# Altium schematic sheet GAP_Periph1.SchDoc
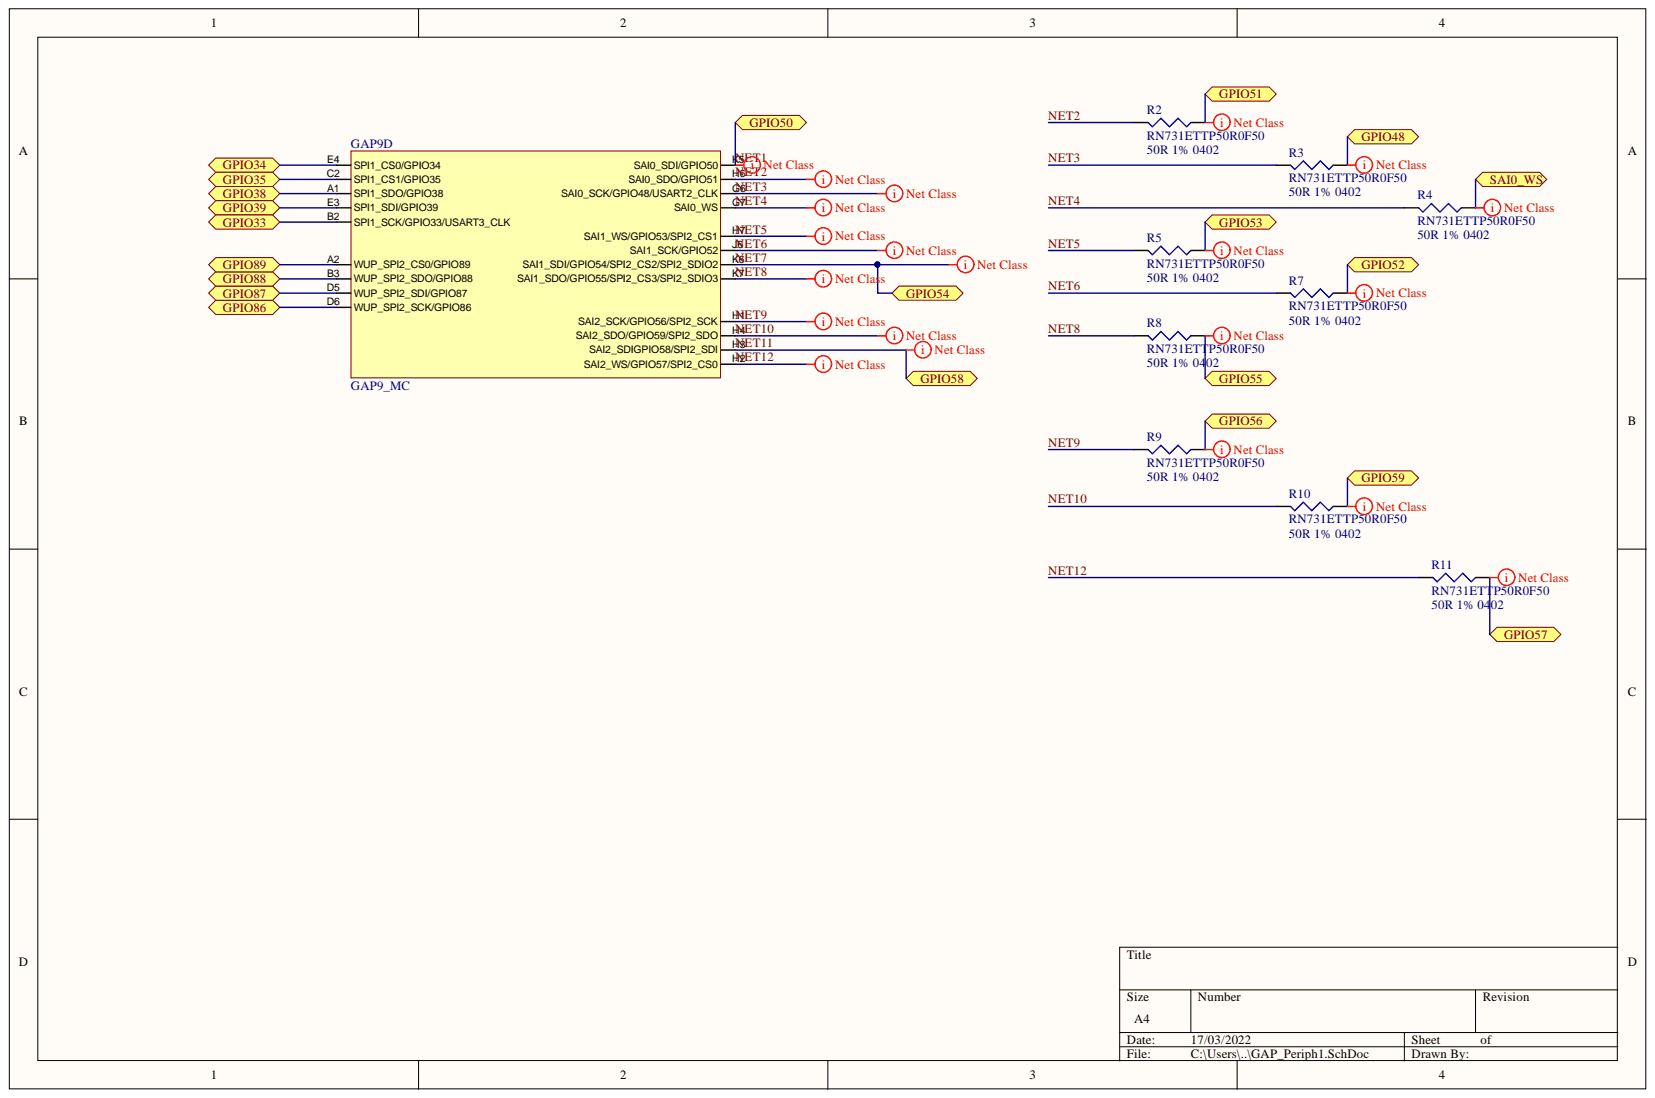
[diagram p0: GAP_Periph1.SchDoc]

## Parts
GAP9  GAP9_MC  [GAP9]  (part D)
R2  RN731ETTP50R0F50  value 50R 1% 0402  [0402]
R3  RN731ETTP50R0F50  value 50R 1% 0402  [0402]
R4  RN731ETTP50R0F50  value 50R 1% 0402  [0402]
R5  RN731ETTP50R0F50  value 50R 1% 0402  [0402]
R7  RN731ETTP50R0F50  value 50R 1% 0402  [0402]
R8  RN731ETTP50R0F50  value 50R 1% 0402  [0402]
R9  RN731ETTP50R0F50  value 50R 1% 0402  [0402]
R10  RN731ETTP50R0F50  value 50R 1% 0402  [0402]
R11  RN731ETTP50R0F50  value 50R 1% 0402  [0402]

## Ports
GPIO33, GPIO34, GPIO35, GPIO38, GPIO39, GPIO48, GPIO50, GPIO51, GPIO52, GPIO53, GPIO54, GPIO55, GPIO56, GPIO57, GPIO58, GPIO59, GPIO86, GPIO87, GPIO88, GPIO89, SAI0_WS

## Nets
GPIO33: GAP9.B2(SPI1_SCK/GPIO33/USART3_CLK) ; port GPIO33
GPIO34: GAP9.E4(SPI1_CS0/GPIO34) ; port GPIO34
GPIO35: GAP9.C2(SPI1_CS1/GPIO35) ; port GPIO35
GPIO38: GAP9.A1(SPI1_SDO/GPIO38) ; port GPIO38
GPIO39: GAP9.E3(SPI1_SDI/GPIO39) ; port GPIO39
GPIO48: R3.1 ; port GPIO48
GPIO51: R2.1 ; port GPIO51
GPIO52: R7.1 ; port GPIO52
GPIO53: R5.1 ; port GPIO53
GPIO55: R8.1 ; port GPIO55
GPIO56: R9.1 ; port GPIO56
GPIO57: R11.1 ; port GPIO57
GPIO59: R10.1 ; port GPIO59
GPIO86: GAP9.D6(WUP_SPI2_SCK/GPIO86) ; port GPIO86
GPIO87: GAP9.D5(WUP_SPI2_SDI/GPIO87) ; port GPIO87
GPIO88: GAP9.B3(WUP_SPI2_SDO/GPIO88) ; port GPIO88
GPIO89: GAP9.A2(WUP_SPI2_CS0/GPIO89) ; port GPIO89
NET1: GAP9.K5(SAI0_SDI/GPIO50) ; port GPIO50
NET2: GAP9.H6(SAI0_SDO/GPIO51) R2.2
NET3: GAP9.G6(SAI0_SCK/GPIO48/USART2_CLK) R3.2
NET4: GAP9.G7(SAI0_WS) R4.2
NET5: GAP9.H7(SAI1_WS/GPIO53/SPI2_CS1) R5.2
NET6: GAP9.J6(SAI1_SCK/GPIO52) R7.2
NET7: GAP9.K6(SAI1_SDI/GPIO54/SPI2_CS2/SPI2_SDIO2) ; port GPIO54
NET8: GAP9.K7(SAI1_SDO/GPIO55/SPI2_CS3/SPI2_SDIO3) R8.2
NET9: GAP9.H1(SAI2_SCK/GPIO56/SPI2_SCK) R9.2
NET10: GAP9.H4(SAI2_SDO/GPIO59/SPI2_SDO) R10.2
NET11: GAP9.H3(SAI2_SDIGPIO58/SPI2_SDI) ; port GPIO58
NET12: GAP9.H2(SAI2_WS/GPIO57/SPI2_CS0) R11.2
SAI0_WS: R4.1 ; port SAI0_WS

## Library data of the parts
R2-R5 R7-R11 = Res3: PT0402FR-070R5L; Component Kind: Standard; LatestRevisionDate: 29-May-2009; LatestRevisionNote: IPC-7351 Footprint Added.; Library Name: Miscellaneous Devices.IntLib; PackageDescription: Chip Resistor; PackageReference: J1-0603; Published: 8-Jun-2000; Signal Integrity: Res; Simulation: RESISTOR; Publisher: Altium Limited
GAP9 = GAP9_MC: Component Kind: Standard; Library Name: GAP9.SchLib
